# Altium schematic sheet Sheet5.SchDoc
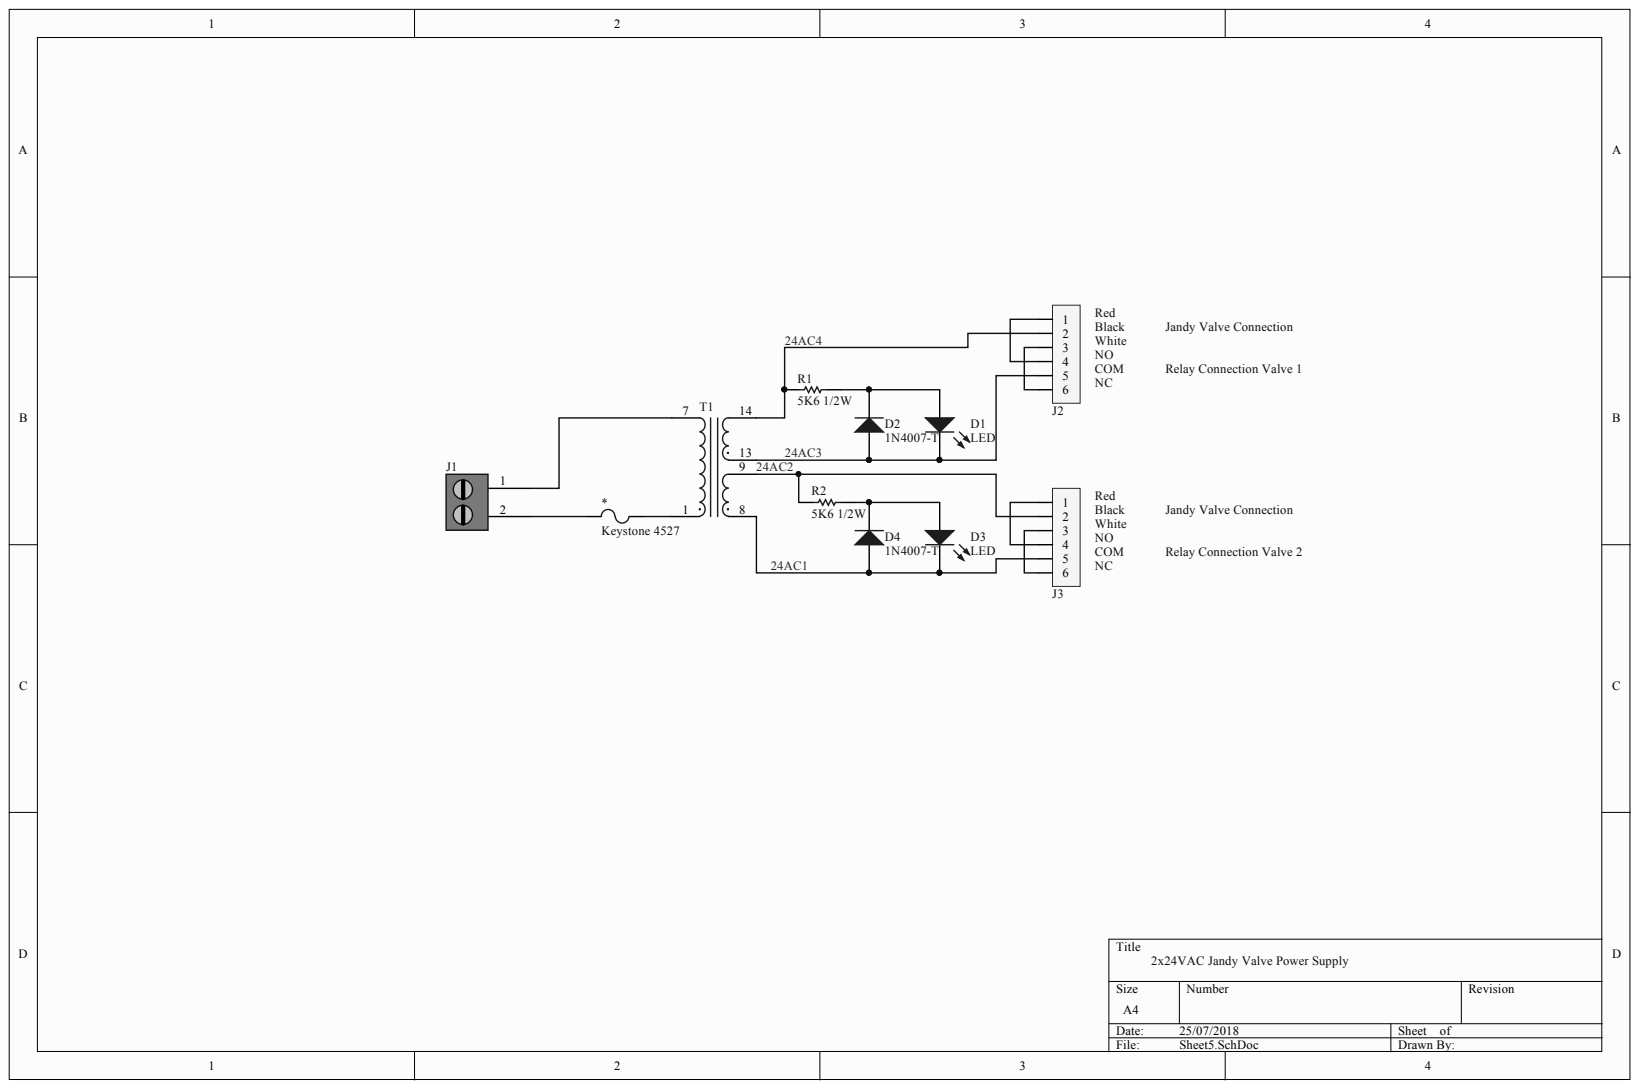
[diagram p0: Sheet5.SchDoc]

## Parts
*  Keystone 4527  [PCBComponent_1 - duplicate]
D1  LED  [PCB-6hmn14p3euwrtpae4j9f-1]
D2  1N4007-T  [DIOD-DO-41-P-2_V]
D3  LED  [PCB-6hmn14p3euwrtpae4j9f-1]
D4  1N4007-T  [DIOD-DO-41-P-2_V]
J1  TE Connectivity 282857-2  [PCB-rsrshy9k52pas9f32mx6-1]  (value not drawn)
J2  Phoenix Contact 1757284  [PCB-gbrv0pb25lbd555ym6el-1]  (value not drawn)
J3  Phoenix Contact 1757284  [PCB-gbrv0pb25lbd555ym6el-1]  (value not drawn)
R1  5K6 1/2W  [PCB-j1411vnnromljbd863ui-1]
R2  5K6 1/2W  [PCB-j1411vnnromljbd863ui-1]
T1  YHDC PCB Transformer. 230VAC Primary, 2x24VAC Secondary  [PCB-4cme8jnyrntyvyjelfo4-1]  (value not drawn)

## Nets
24AC1: D3.2 D4.1 J3.5 T1.8
24AC2: J3.2 R2.1 T1.9
24AC3: D1.2 D2.1 J2.5 T1.13
24AC4: J2.2 R1.1 T1.14
Net*_1: *.1 J1.2
Net*_2: *.2 T1.1
NetD1_1: D1.1 D2.2 R1.2
NetD3_1: D3.1 D4.2 R2.2
NetJ1_1: J1.1 T1.7
NetJ2_1: J2.1 J2.4
NetJ2_3: J2.3 J2.6
NetJ3_1: J3.1 J3.4
NetJ3_3: J3.3 J3.6

## Library data of the parts
* = CMP-b9bb70b18215667c-7: Fuse holder; 5amp; 250v; Nylon Base only; Brass Tin plated (contacts); Manufacturer Part Number: 4527; Current Rating: 5.00 A; Lead-Free Status: Lead Free; Number of Circuits: 1; Pin Pitch: 21.8 mm; Color: Black; Component Kind: Standard; Contact Material: Brass; Contact Plating: Tin; Flammability Rating: UL 94 V-0; Library Name: FavoritesLibrary; Material: Brass; Mounting Style: Panel, Axial; OctopartID: b9bb70b18215667c; Octopart URL: http://octopart.com/4527-keystone-7880; Packaging: Bulk; RoHS: Compliant; Size-Height: 10.8 mm; Size-Length: 24.2 mm; Size-Width: 9.70 mm; Voltage Rating (DC): 250 V
D1 D3 = CMP-9d1f5758637d42d8-1: TLHR5205 Red LED, 625 nm 5mm (T-1 3/4), Round Lens Through Hole package; Forward Voltage: 2.00 V; Lead-Free Status: Lead Free; Lead Length: 24.0 mm; Luminous Intensity: 70.0 mcd; Peak Wavelength: 635 nm; Viewing Angle: 14 °; Wavelength: 625 nm; Color: Red; Component Kind: Standard; Library Name: CommunityVault; Manufacturer Part Number: TLHR5205; Mounting Style: Through Hole, Radial; Number of Pins: 2; OctopartID: 9d1f5758637d42d8; Octopart URL: http://octopart.com/tlhr5205-vishay-55433988; RoHS: Compliant; Voltage Rating (DC): 2.00 V
D2 D4 = CMP-815216-8: 1.0 A Rectifier, 8 pF, 1000 V, -65 to 150 degC, 2-Pin DO-41 Plastic, RoHS, Tape and Reel; Capacitance: 8e-12 F; Current Rating: 1.0 A; Lead-Free Status: Lead Free; Polarity: Standard; Voltage Rating (DC): 1000.0 V; Case/Package: DO-41; Component Kind: Standard; Library Name: CommunityVault; Lifecycle Status: Active; Mounting Style: Through Hole; Number of Pins: 2; OctopartID: e80c224be0e437cb; Octopart Url: https://octopart.com/1n4007-t-diodes+inc.-55389582; REACH SVHC Compliance: No SVHC; RoHS: Compliant
J1 = CMP-6678ad2915e7b9bf-2: Wire to Board 2 Position 5.08 mm Pitch 30-12 AWG Euro Style Terminal Block; ELV Compliant: Compliant; Lead-Free Status: Lead Free; Number of Contacts: 2; Pin Pitch: 5.08 mm; Color: Green; Component Kind: Standard; Contact Material: Brass; Contact Plating: Tin; Flammability Rating: UL 94 V-0; Housing Color: Green; Housing Material: Nylon 6/6; Library Name: CommunityVault; Lifecycle Status: Active; Manufacturer Part Number: 282857-2; Mounting Style: Through Hole, Screw; Number of Positions: 2; Number of Rows: 1; OctopartID: 6678ad2915e7b9bf; Octopart URL: http://octopart.com/282857-2-te+connectivity-39540473; Packaging: Box; RoHS: Compliant; Size-Height: 19.0 mm; Size-Length: 10.2 mm; Size-Width: 10.0 mm
J2 J3 = CMP-3802f109acaee7c5-1: Terminal Header; 12 A; 250 V; 5.08 mm; 6; Solder Mount; 1.4 mm; 4 kV; 320 V; Current Rating: 12.0 A; Gender: Male; Lead-Free Status: Lead Free; Mounting Angle: 90.0 °; Number of Contacts: 6; Pin Pitch: 5.08 mm; Voltage Rating (AC): 250 V; Voltage Rating (DC): 320 V; Color: Green; Component Kind: Standard; Flammability Rating: UL 94 V-0; Housing Color: Green; Housing Material: Plastic; Library Name: CommunityVault; Manufacturer Part Number: 1757284; Mounting Style: PCB, Through Hole, Solder; Number of Positions: 6; Number of Rows: 1; OctopartID: 3802f109acaee7c5; Octopart URL: http://octopart.com/1757284-phoenix+contact-111050; REACH SVHC Compliance: No SVHC; RoHS: Compliant; Size-Height: 8.60 mm; Size-Length: 32.5 mm; Size-Width: 25.4 mm
R1 R2 = CMP-cf680747e65110af-1: Res Carbon Film 5.6K Ohm 5% 1/2W -400ppm/°C to 0ppm/°C Conformal AXL Thru-Hole T/R; Component Kind: Standard; Library Name: CommunityVault; Mounting Style: Axial, Through Hole; OctopartID: cf680747e65110af; Resistance Tolerance: ±5%
T1 = CMP-buw0ev2etz0pkjsi8ng8-2: Component Kind: Standard; CustomComponentID: buw0ev2etz0pkjsi8ng8; Description: YHDC PCB Transformer. 230VAC Primary, 2x24VAC Secondary; Library Name: CommunityVault
